# Altium schematic sheet RF.SCHDOC(RF)
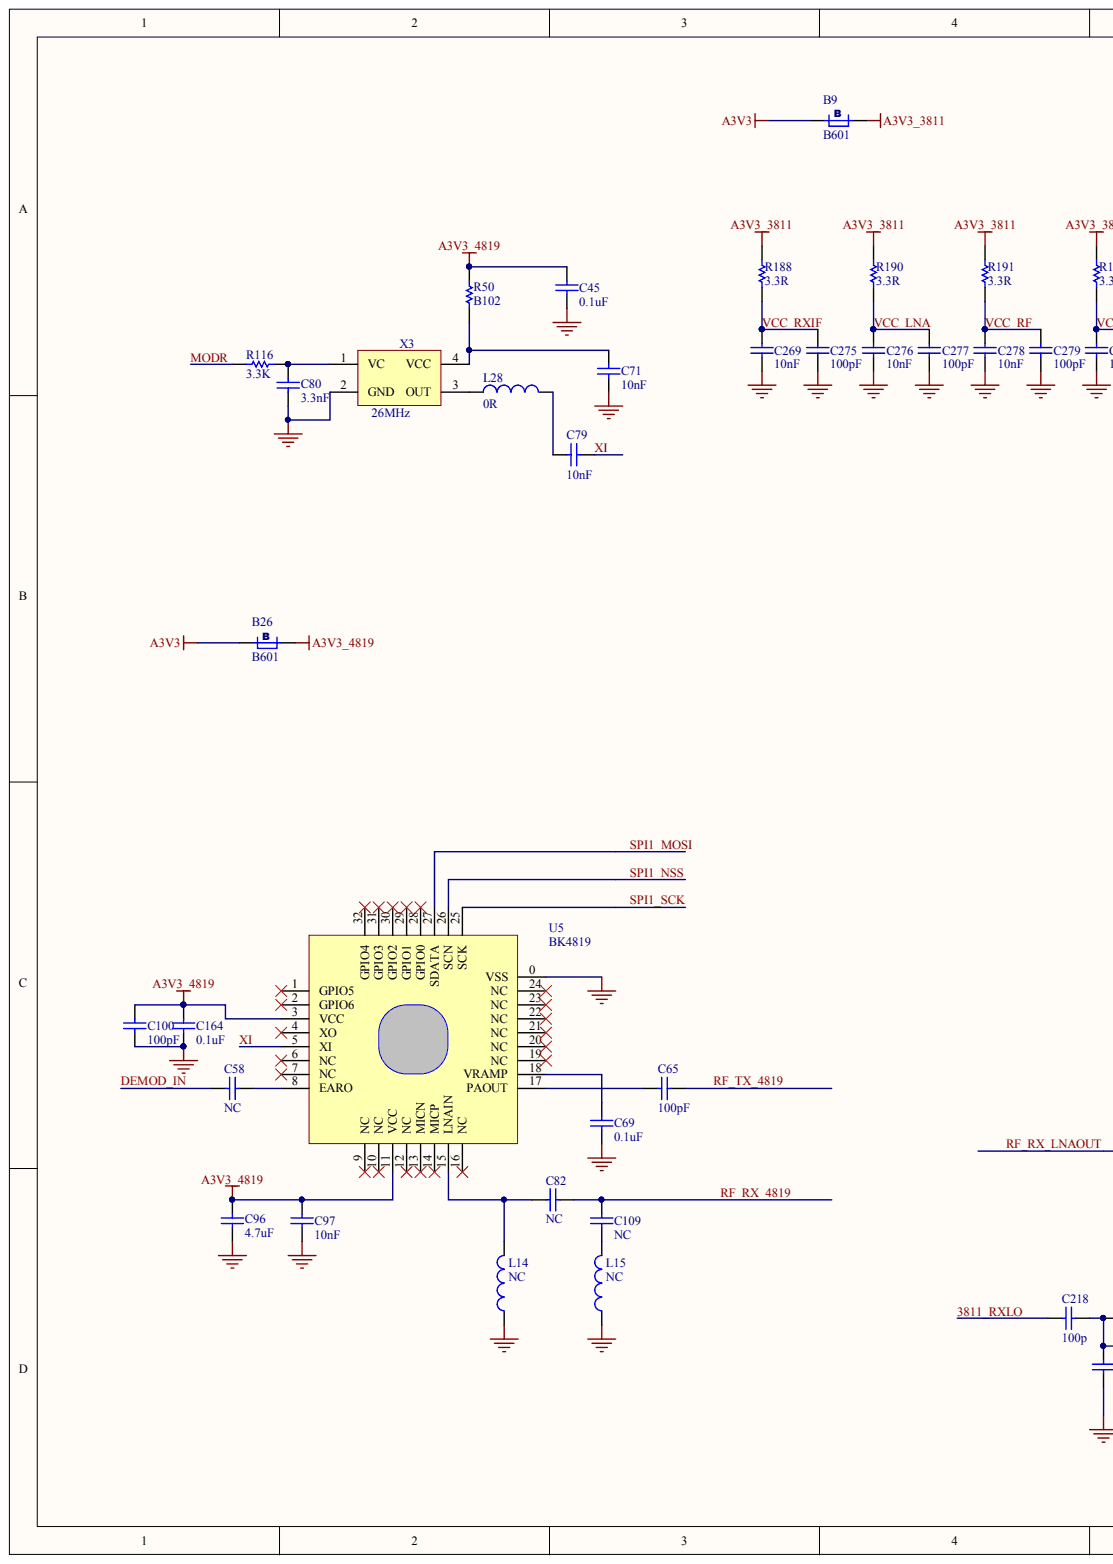
[diagram p0: RF.SCHDOC, left]
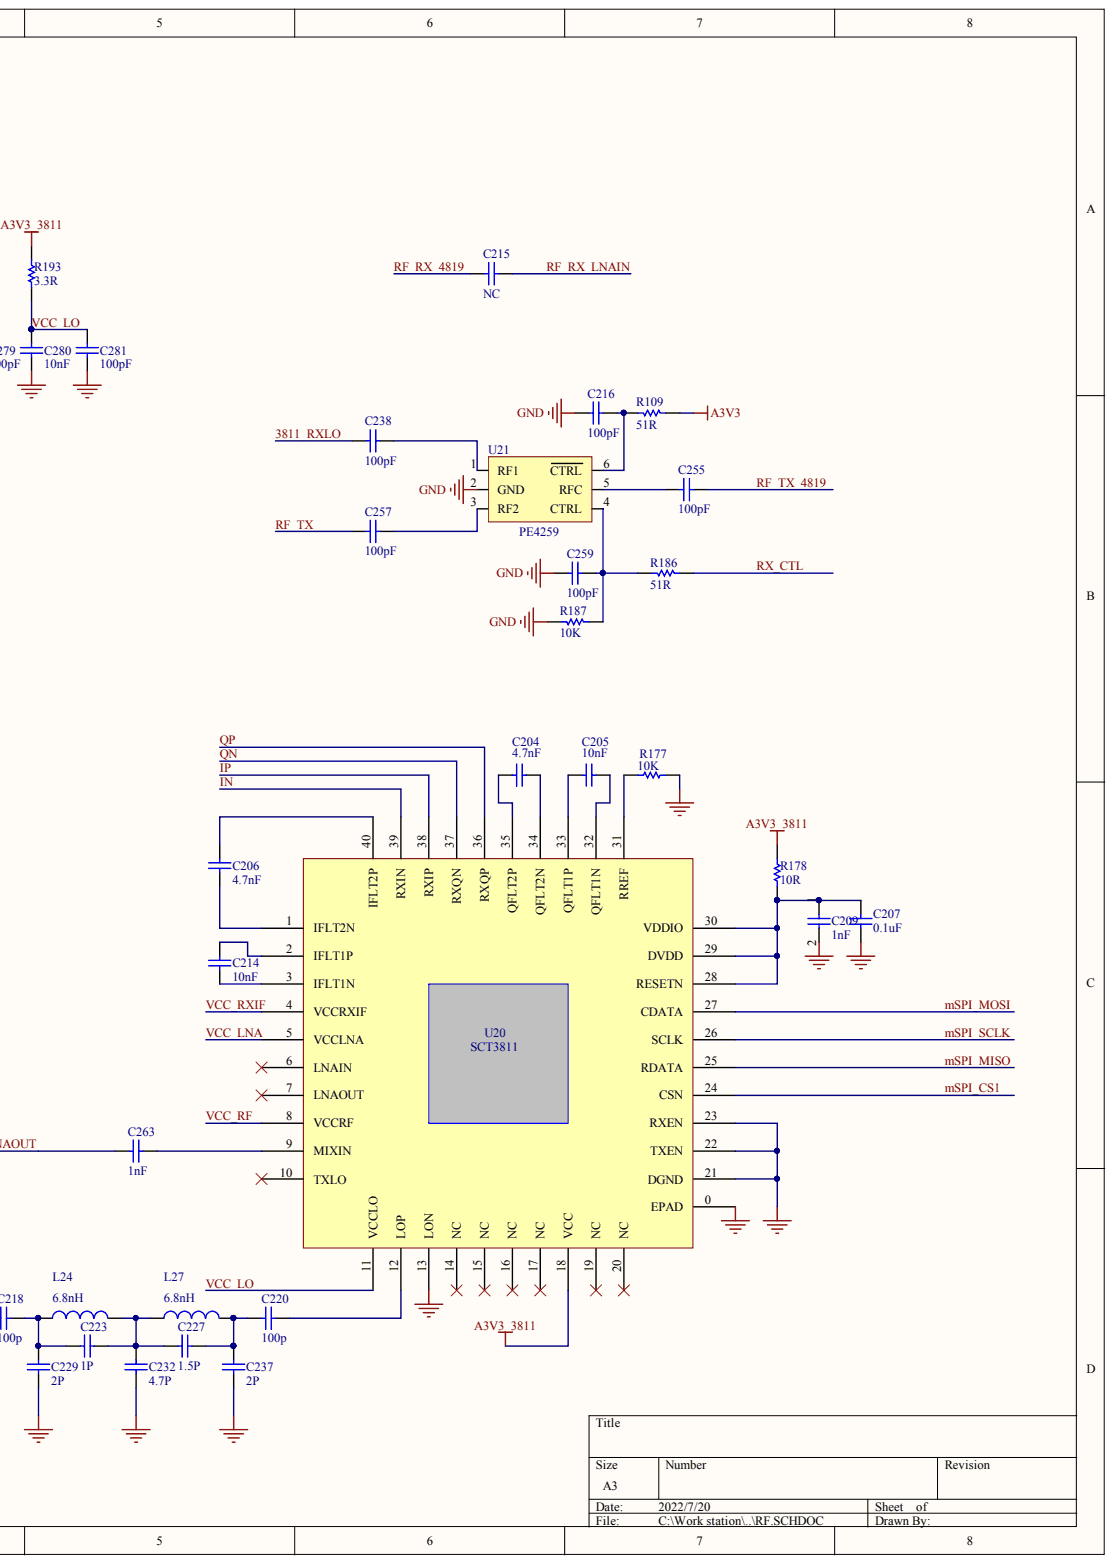
[diagram p1: RF.SCHDOC, right]

## Parts
B9  B601  [0402]
B26  B601  [0402]
C45  0.1uF  [0402]
C58  NC  [0402]
C65  100pF  [0402]
C69  0.1uF  [0402]
C71  10nF  [0402]
C79  10nF  [0402]
C80  3.3nF  [0402]
C82  NC  [0402]
C96  4.7uF  [0402]
C97  10nF  [0402]
C100  100pF  [0402]
C109  NC  [0402]
C164  0.1uF  [0402]
C204  4.7nF  [0402]
C205  10nF  [0402]
C206  4.7nF  [0402]
C207  0.1uF  [0402]
C209  1nF  [0402]
C214  10nF  [0402]
C215  NC  [0402]
C216  100pF  [0402]
C218  100p  [0402]
C220  100p  [0402]
C223  1P  [0402]
C227  1.5P  [0402]
C229  2P  [0402]
C232  4.7P  [0402]
C237  2P  [0402]
C238  100pF  [0402]
C255  100pF  [0402]
C257  100pF  [0402]
C259  100pF  [0402]
C263  1nF  [0402]
C269  10nF  [0402]
C275  100pF  [0402]
C276  10nF  [0402]
C277  100pF  [0402]
C278  10nF  [0402]
C279  100pF  [0402]
C280  10nF  [0402]
C281  100pF  [0402]
L14  NC  [0402]
L15  NC  [0402]
L24  6.8nH  [0402]
L27  6.8nH  [0402]
L28  0R  [0402]
R50  B102  [0402]
R109  51R  [0402]
R116  3.3K  [0402]
R177  10K  [0402]
R178  10R  [0402]
R186  51R  [0402]
R187  10K  [0402]
R188  3.3R  [0402]
R190  3.3R  [0402]
R191  3.3R  [0402]
R193  3.3R  [0402]
U5  BK4819  [QFN32_4X4]
U20  SCT3811  [QFN40_6X6]
U21  PE4259  [SC70-6]
X3  26MHz  [SMD2520_jun]

## Nets
A3V3: B9.2 B26.2 R109.1
A3V3_3811: B9.1 R178.2 R188.2 R190.2 R191.2 R193.2 U20.18(VCC)
A3V3_4819: B26.1 C45.1 C96.2 C97.1 C100.1 C164.1 R50.1 U5.3(VCC) U5.11(VCC)
GND: C45.2 C69.2 C71.1 C80.1 C96.1 C97.2 C100.2 C164.2 C207.2 C209.2 C216.2 C229.2 C232.2 C237.2 C259.2 C269.2 C275.2 C276.2 C277.2 C278.2 C279.2 C280.2 C281.2 L14.1 L15.1 R177.2 R187.2 U5.0(VSS) U20.0(EPAD) U20.13(LON) U20.21(DGND) U20.22(TXEN) U20.23(RXEN) U21.2(GND) X3.2(GND)
3811_RXLO: C218.2 C238.1
DEMOD_IN: C58.1
IN: U20.39(RXIN)
IP: U20.38(RXIP)
MODR: R116.2
MSPI_CS1: U20.24(CSN)
MSPI_MISO: U20.25(RDATA)
MSPI_MOSI: U20.27(CDATA)
MSPI_SCLK: U20.26(SCLK)
QN: U20.37(RXQN)
QP: U20.36(RXQP)
RF_RX_4819: C82.1 C109.2 C215.1
RF_RX_LNAIN: C215.2
RF_RX_LNAOUT: C263.1
RF_TX: C257.1
RF_TX_4819: C65.2 C255.2
RX_CTL: R186.1
SPI1_MOSI: U5.27(SDATA)
SPI1_NSS: U5.26(SCN)
SPI1_SCK: U5.25(SCK)
VCC_LNA: C276.1 C277.1 R190.1 U20.5(VCCLNA)
VCC_LO: C280.1 C281.1 R193.1 U20.11(VCCLO)
VCC_RF: C278.1 C279.1 R191.1 U20.8(VCCRF)
VCC_RXIF: C269.1 C275.1 R188.1 U20.4(VCCRXIF)
XI: C79.1 U5.5(XI)
NetC58_2: C58.2 U5.8(EARO)
NetC65_1: C65.1 U5.17(PAOUT)
NetC69_1: C69.1 U5.18(VRAMP)
NetC71_2: C71.2 R50.2 X3.4(VCC)
NetC79_2: C79.2 L28.2
NetC80_2: C80.2 R116.1 X3.1(VC)
NetC82_2: C82.2 L14.2 U5.15(LNAIN)
NetC109_1: C109.1 L15.2
NetC204_1: C204.1 U20.34(QFLT2N)
NetC204_2: C204.2 U20.35(QFLT2P)
NetC205_1: C205.1 U20.32(QFLT1N)
NetC205_2: C205.2 U20.33(QFLT1P)
NetC206_1: C206.1 U20.1(IFLT2N)
NetC206_2: C206.2 U20.40(IFLT2P)
NetC207_1: C207.1 C209.1 R178.1 U20.28(RESETN) U20.29(DVDD) U20.30(VDDIO)
NetC214_1: C214.1 U20.3(IFLT1N)
NetC214_2: C214.2 U20.2(IFLT1P)
NetC216_1: C216.1 R109.2 U21.6(CTRL)
NetC218_1: C218.1 C223.1 C229.1 L24.1
NetC220_1: C220.1 U20.12(LOP)
NetC220_2: C220.2 C227.2 C237.1 L27.2
NetC223_2: C223.2 C227.1 C232.1 L24.2 L27.1
NetC238_2: C238.2 U21.1(RF1)
NetC255_1: C255.1 U21.5(RFC)
NetC257_2: C257.2 U21.3(RF2)
NetC259_1: C259.1 R186.2 R187.1 U21.4(CTRL)
NetC263_2: C263.2 U20.9(MIXIN)
NetL28_1: L28.1 X3.3(OUT)
NetR177_1: R177.1 U20.31(RREF)

Not connected: U5 1(GPIO5) 2(GPIO6) 4(XO) 6(NC) 7(NC) 9(NC) 10(NC) 12(NC) 13(MICN) 14(MICP) 16(NC) 19(NC) 20(NC) 21(NC) 22(NC) 23(NC) 24(NC) 28(GPIO0) 29(GPIO1) 30(GPIO2) 31(GPIO3) 32(GPIO4); U20 6(LNAIN) 7(LNAOUT) 10(TXLO) 14(NC) 15(NC) 16(NC) 17(NC) 19(NC) 20(NC)

## Library data of the parts
B9 B26 = B: Component Kind: Standard; Library Name: SCH Lib.SCHLIB; Revision: July-2002: Re-released for DXP Platform.
C45 C69 C164 = Cap Semi: Capacitor (Semiconductor SIM Model); Component Kind: Standard; Library Name: Miscellaneous Devices.IntLib; Published: 8-Jun-2000; Revision: July-2002: Re-released for DXP Platform.; Signal Integrity: Cap Semi; Simulation: CAP; Value: 0.1uF; Publisher: Altium Limited
C58 C65 C71 C79 C80 C82 C96 C97 C100 = Cap Semi: Capacitor (Semiconductor SIM Model); Value: 0.1uF; Component Kind: Standard; Library Name: Miscellaneous Devices.IntLib; Published: 8-Jun-2000; Revision: July-2002: Re-released for DXP Platform.; Signal Integrity: Cap Semi; Simulation: CAP; Publisher: Altium Limited
C109 C204-C207 C209 C214 C263 C269 C275-C281 = Cap: Capacitor; Manufacturer: Generic Components; Class: Passive; Component Kind: Standard; Library Name: Miscellaneous Devices.IntLib; Published: 08/06/2000; Signal Integrity: Cap; Simulation: CAP; SubClass: Capacitor; Publisher: Altium Hobart Technology Centre
C215 C216 C238 C255 C257 C259 = Cap: Capacitor SMD; Component Kind: Standard; LatestRevisionDate: 05-oct-2007  -  Semtech; LatestRevisionNote: Re-released for DXP Platform.; Published: 8-Jun-2000; Signal Integrity: Cap Semi; Simulation: CAP; Publisher: Altium Limited
  C216 C238 C255 C259: Library Name: SX1262_e428v03a.SchLib
C218 C220 C223 C227 C229 C232 C237 = Cap: Capacitor; Manufacturer: Generic Components; Class: Passive; Value: 100pF; Component Kind: Standard; Library Name: Miscellaneous Devices.IntLib; Published: 08/06/2000; Signal Integrity: Cap; Simulation: CAP; SubClass: Capacitor; Publisher: Altium Hobart Technology Centre
L14 L15 L28 = Inductor: Manufacturer: Generic Components; Class: Passive; Component Kind: Standard; Description: Inductor; Library Name: Miscellaneous Devices.IntLib; Published: 08/06/2000; Signal Integrity: Inductor; Simulation: INDUCTOR; SubClass: Inductor; Publisher: Altium Hobart Technology Centre
L24 L27 = Inductor: Value: 47nH; Component Kind: Standard; Description: Inductor; Library Name: Miscellaneous Devices.IntLib; Published: 8-Jun-2000; Revision: July-2002: Re-released for DXP Platform.; Signal Integrity: Inductor; Simulation: INDUCTOR; Publisher: Altium Limited
R50 R116 = Res Semi: Semiconductor Resistor; Manufacturer: Generic Components; Class: Passive; Value: 1K; Component Kind: Standard; Library Name: Miscellaneous Devices.IntLib; Published: 08/06/2000; Signal Integrity: Res Semi; Simulation: RES; SubClass: Resistor; Publisher: Altium Hobart Technology Centre
R109 R186 R187 = Res1: Resistor; Value: 0; Component Kind: Standard; Library Name: Miscellaneous Devices.IntLib; Published: 8-Jun-2000; Revision: July-2002: Re-released for DXP Platform.; Signal Integrity: Res; Simulation: RESISTOR; Publisher: Altium Limited
R177 R178 R188 R190 R191 R193 = Res Semi: Semiconductor Resistor; Manufacturer: Generic Components; Class: Passive; Component Kind: Standard; Library Name: Miscellaneous Devices.IntLib; Published: 08/06/2000; Signal Integrity: Res Semi; Simulation: RES; SubClass: Resistor; Publisher: Altium Hobart Technology Centre
U20 = CMX994: Component Kind: Standard; Library Name: SCH Lib.SCHLIB
U21 = PE4259 RF Switch: PE4259 Peregrine RF Switch; Component Kind: Standard; LatestRevisionDate: 03-Sep-2012 -  Semtech
X3 = TCXO_12.8MHZ: Component Kind: Standard; Library Name: Schlib1.SchLib
U5 = BK4819: Component Kind: Standard; Library Name: SCH Lib.SCHLIB
